# Altium schematic sheet pulser one pad.SchDoc
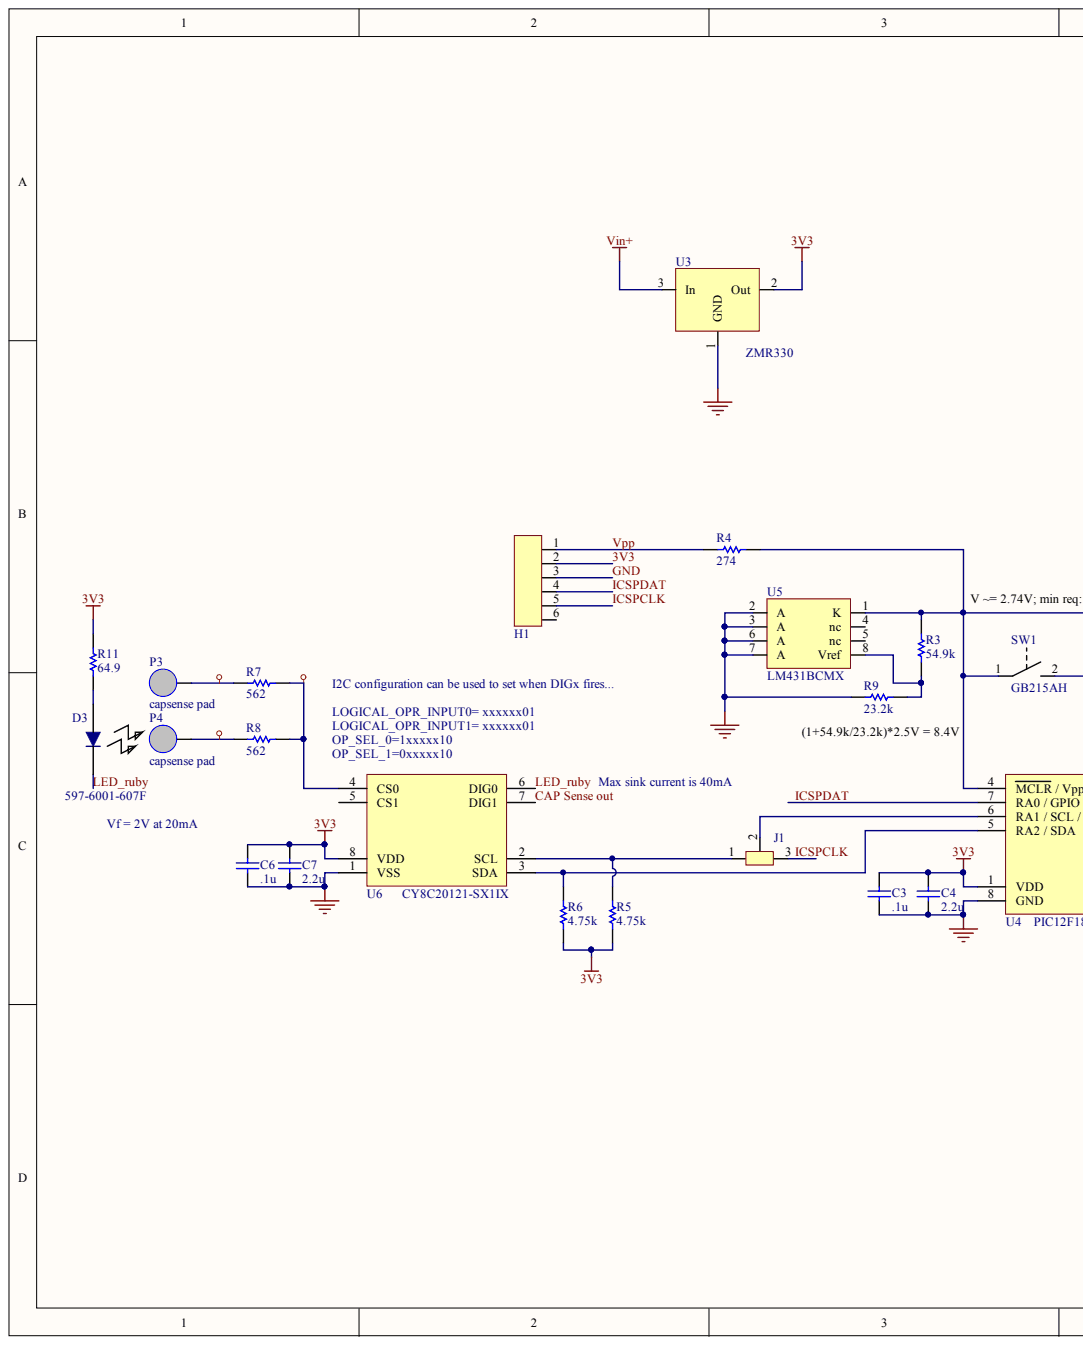
[diagram p0: pulser one pad.SchDoc, left]
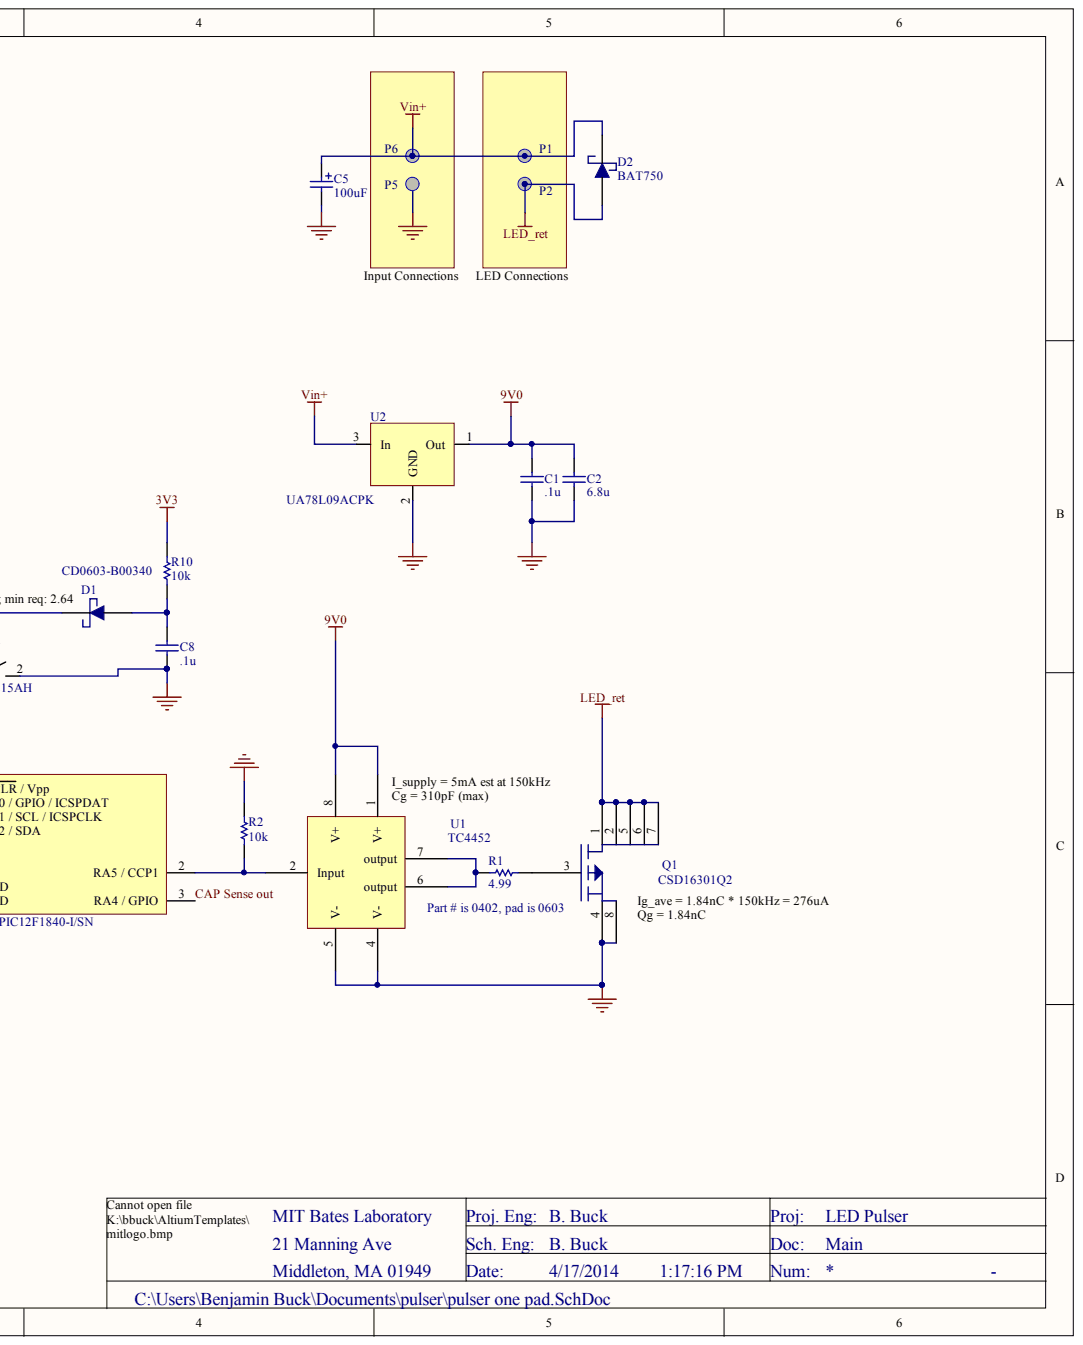
[diagram p1: pulser one pad.SchDoc, right]

## Parts
C1  value .1u  (hidden comment: Cap)
C2  value 6.8u  (hidden comment: Cap)
C3  value .1u  (hidden comment: Cap)
C4  value 2.2u  (hidden comment: Cap)
C5  value 100uF  (hidden comment: CapPol)
C6  value .1u  (hidden comment: Cap)
C7  value 2.2u  (hidden comment: Cap)
C8  value .1u  (hidden comment: Cap)
D1  CD0603-B00340
D2  BAT750
D3  597-6001-607F
H1  6 pin header  (value not drawn)
J1  jumper  (value not drawn)
P1  16AWG Solder Point  (value not drawn)
P2  16AWG Solder Point  (value not drawn)
P3  capsense pad
P4  capsense pad
P5  16AWG Solder Point  (value not drawn)
P6  16AWG Solder Point  (value not drawn)
Q1  CSD16301Q2
R1  value 4.99  (hidden comment: Res)
R2  value 10k  (hidden comment: Res)
R3  value 54.9k  (hidden comment: Res)
R4  value 274  (hidden comment: Res)
R5  value 4.75k  (hidden comment: Res)
R6  value 4.75k  (hidden comment: Res)
R7  value 562  (hidden comment: Res)
R8  value 562  (hidden comment: Res)
R9  value 23.2k  (hidden comment: Res)
R10  value 10k  (hidden comment: Res)
R11  value 64.9  (hidden comment: Res)
SW1  GB215AH
U1  TC4452
U2  UA78L09ACPK
U3  ZMR330
U4  PIC12F1840-I/SN
U5  LM431BCMX
U6  CY8C20121-SX1I  PartNumber: CY8C20121-SX1IX

## Nets
9V0: C1.2 C2.2 U1.1(V+) U1.8(V+) U2.1
LED_RET: D2.2 P2.1 Q1.1 Q1.2 Q1.5 Q1.6 Q1.7
VIN+: C5.1 D2.1 P1.1 P6.1 U2.3 U3.3
3V3: C3.2 C4.2 C6.2 C7.2 H1.2 R5.2 R6.2 R10.1 R11.2 U3.2 U4.1(VDD) U6.8(VDD)
CAP SENSE OUT: U4.3(RA4_/_GPIO) U6.7(DIG1)
GND: C1.1 C2.1 C3.1 C4.1 C5.2 C6.1 C7.1 C8.1 H1.3 P5.1 Q1.4 Q1.8 R2.2 R9.2 SW1.2 U1.4(V-) U1.5(V-) U2.2 U3.1 U4.8(GND) U5.2(A) U5.3(A) U5.6(A) U5.7(A) U6.1(VSS)
ICSPCLK: H1.5 J1.3
ICSPDAT: H1.4 U4.7(RA0_/_GPIO_/_ICSPDAT)
LED_RUBY: D3.K U6.6(DIG0)
VPP: H1.1 R4.2
NetC8_2: C8.2 D1.2 R10.2
NetD1_1: D1.1 R3.2 R4.1 SW1.1 U4.4(MCLR_/_Vpp) U5.1(K)
NetD3_A: D3.A R11.1
NetJ1_1: J1.1 R5.1 U6.2(SCL)
NetJ1_2: J1.2 U4.6(RA1_/_SCL_/_ICSPCLK)
NetP3_1: P3.1 R7.2
NetP4_1: P4.1 R8.2
NetQ1_3: Q1.3 R1.1
NetR1_2: R1.2 U1.6(output) U1.7(output)
NetR2_1: R2.1 U1.2(Input) U4.2(RA5_/_CCP1)
NetR3_1: R3.1 R9.1 U5.8(Vref)
NetR6_1: R6.1 U4.5(RA2_/_SDA) U6.3(SDA)
NetR7_1: R7.1 R8.1 U6.4(CS0)

Not connected: H1 6; U5 4(nc) 5(nc); U6 5(CS1)

## Library data of the parts
C1 C3 C6 C8 = (no symbol name): PartNumber: 250R14W104KV4T; Manufacturer: Johanson Dielectrics
C2 = (no symbol name): PartNumber: C4532X5R1H685K250KA; Manufacturer: TDK
C4 C7 = (no symbol name): PartNumber: C1608X5R1V225K080AC; Manufacturer: TDK
C5 = (no symbol name): PartNumber: T495X476K035ATE300; Manufacturer: Kemet
D1 = (no symbol name): Manufacturer: Bourns; PartNumber: CD0603-B00340
D2 = (no symbol name): Manufacturer: Diodes / Zetex; PartNumber: BAT750
D3 = (no symbol name): Manufacturer: Dialight; PartNumber: 597-6001-607F
Q1 U2 = (no symbol name): Manufacturer: Texas Instruments
  Q1: PartNumber: CSD16301Q2
  U2: PartNumber: UA78L09ACPK
R1 = (no symbol name): PartNumber: CRCW04024R99FK; Manufacturer: Vishay / Dale
R2 R10 = (no symbol name): PartNumber: CRCW060310K0FK; Manufacturer: Vishay / Dale
R3 = (no symbol name): PartNumber: CRCW060354K9FK; Manufacturer: Vishay / Dale
R4 = (no symbol name): PartNumber: CRCW0603274RFK; Manufacturer: Vishay / Dale
R5 R6 = (no symbol name): PartNumber: CRCW06034K75FK; Manufacturer: Vishay / Dale
R7 R8 = (no symbol name): PartNumber: CRCW0603562RFK; Manufacturer: Vishay / Dale
R9 = (no symbol name): PartNumber: CRCW060323K2FK; Manufacturer: Vishay / Dale
R11 = (no symbol name): PartNumber: CRCW060364R9FK; Manufacturer: Vishay / Dale
SW1 = (no symbol name): Manufacturer: NKK Switches; PartNumber: GB215AH
U1 = (no symbol name): Manufacturer: Microchip; PartNumber: TC4452VOA
U3 = (no symbol name): Manufacturer: Diodes; PartNumber: ZMR330FTA
U4 = (no symbol name): Manufacturer: Microchip; PartNumber: PIC12F1840-I/SN
U5 = (no symbol name): Manufacturer: Fairchild Semiconductor; PartNumber: LM431ACMX
U6 = (no symbol name): Manufacturer: Cypress
